# Altium schematic sheet Easy380 Controllo.SchDoc("Easy380 Controllo")
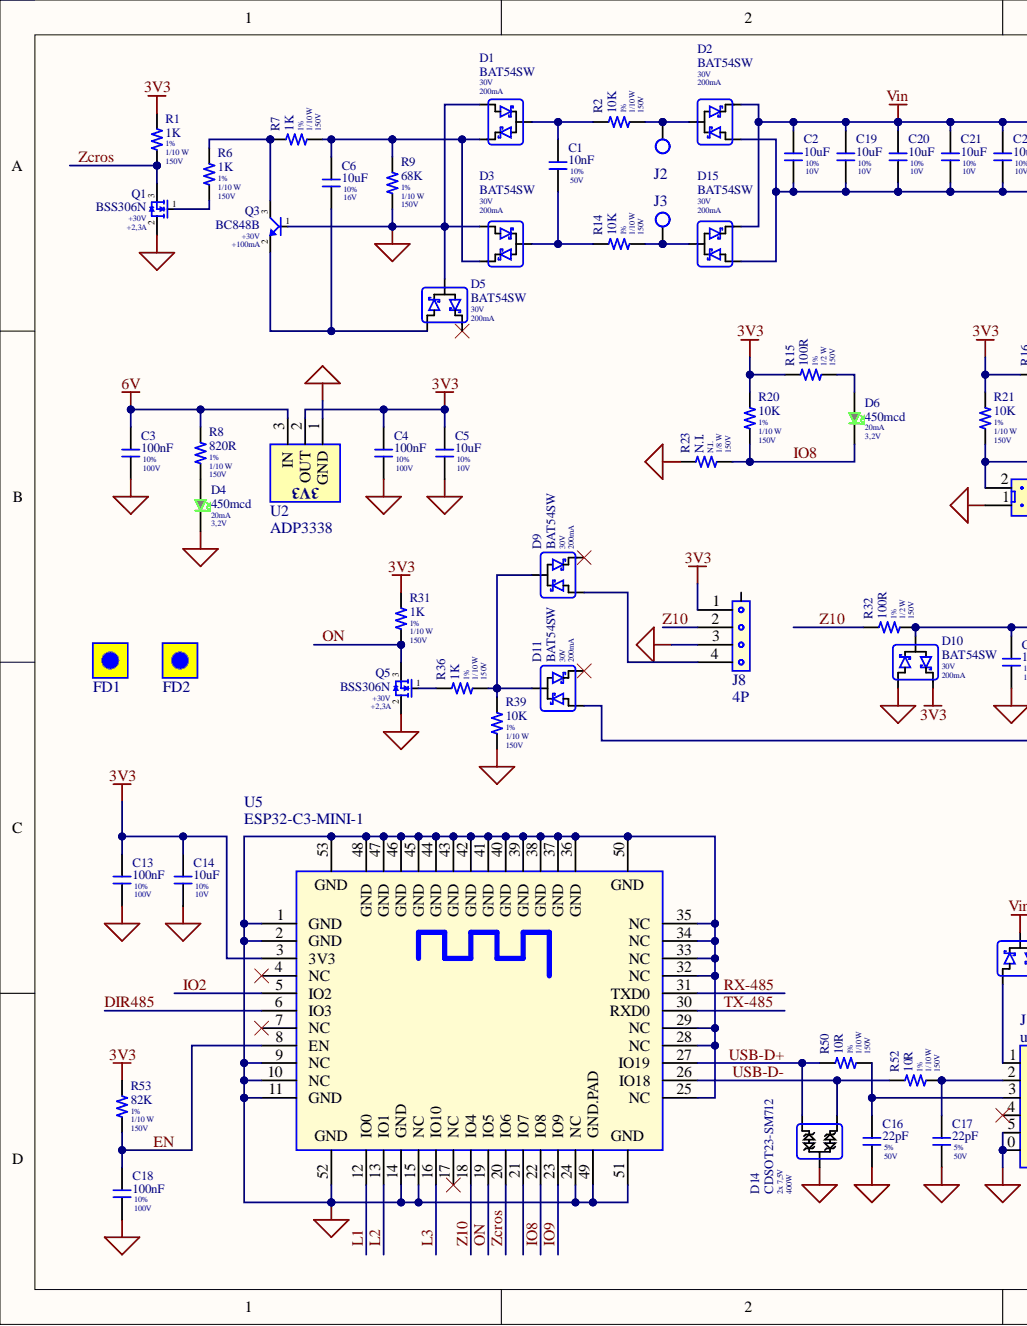
[diagram p0: Easy380 Controllo.SchDoc, left]
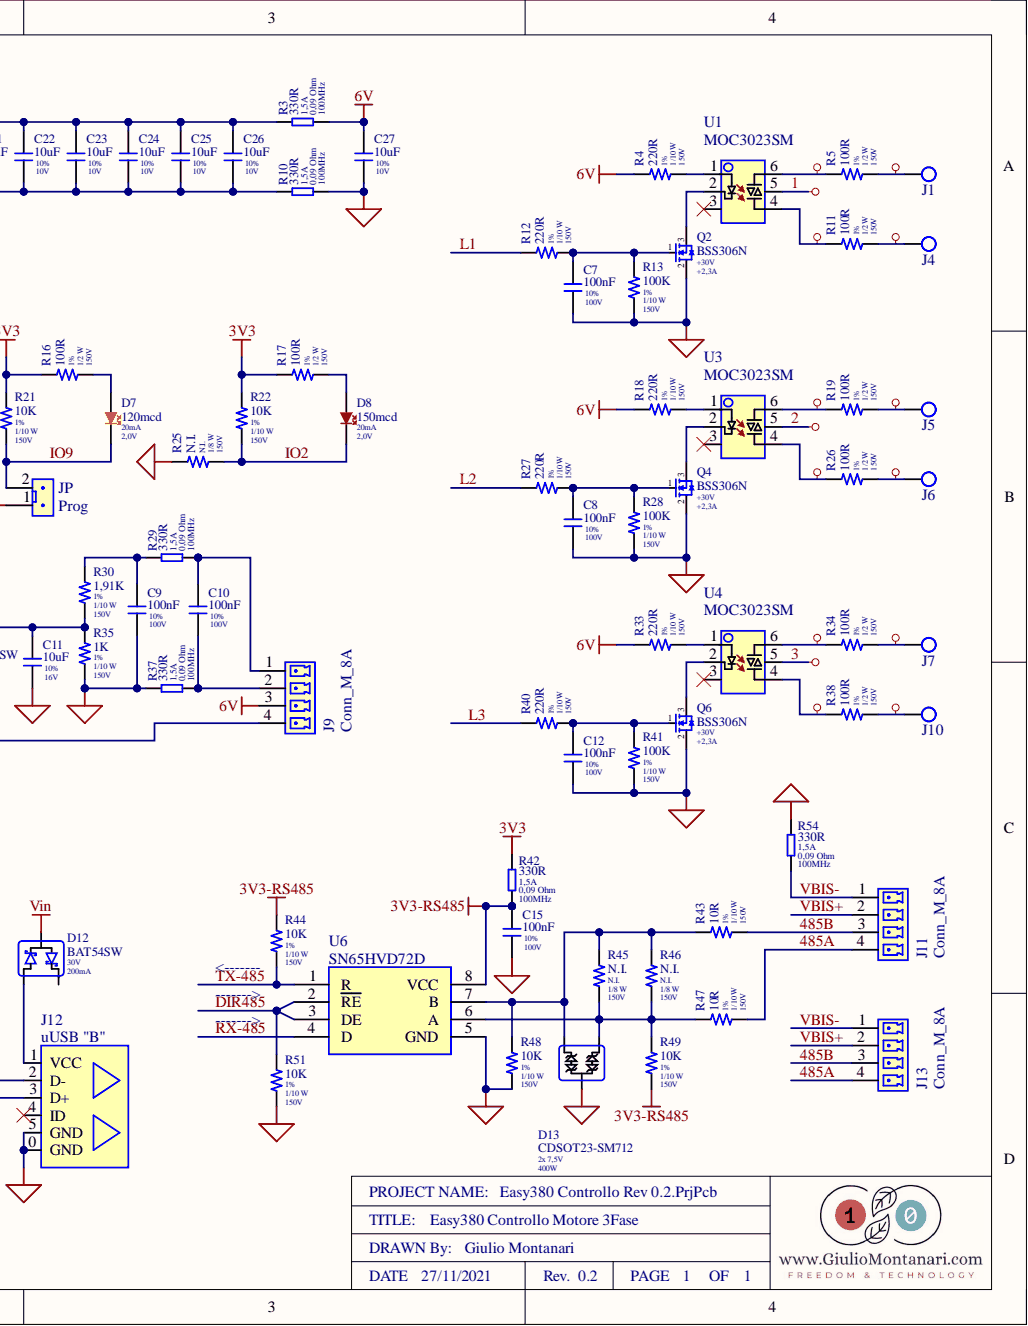
[diagram p1: Easy380 Controllo.SchDoc, right]

## Parts
C1  10nF  Tolerance: 10%  Voltage: 50V  [CAP_0805]
C2  10uF  Tolerance: 10%  Voltage: 10V  [CAP_0805]
C3  100nF  Tolerance: 10%  Voltage: 100V  [CAP_0805]
C4  100nF  Tolerance: 10%  Voltage: 100V  [CAP_0805]
C5  10uF  Tolerance: 10%  Voltage: 10V  [CAP_0805]
C6  10uF  Tolerance: 10%  Voltage: 16V  [CAP_0805]
C7  100nF  Tolerance: 10%  Voltage: 100V  [CAP_0805]
C8  100nF  Tolerance: 10%  Voltage: 100V  [CAP_0805]
C9  100nF  Tolerance: 10%  Voltage: 100V  [CAP_0805]
C10  100nF  Tolerance: 10%  Voltage: 100V  [CAP_0805]
C11  10uF  Tolerance: 10%  Voltage: 16V  [CAP_0805]
C12  100nF  Tolerance: 10%  Voltage: 100V  [CAP_0805]
C13  100nF  Tolerance: 10%  Voltage: 100V  [CAP_0805]
C14  10uF  Tolerance: 10%  Voltage: 10V  [CAP_0805]
C15  100nF  Tolerance: 10%  Voltage: 100V  [CAP_0805]
C16  22pF  Tolerance: 5%  Voltage: 50V  [CAP_0805]
C17  22pF  Tolerance: 5%  Voltage: 50V  [CAP_0805]
C18  100nF  Tolerance: 10%  Voltage: 100V  [CAP_0805]
C19  10uF  Tolerance: 10%  Voltage: 10V  [CAP_0805]
C20  10uF  Tolerance: 10%  Voltage: 10V  [CAP_0805]
C21  10uF  Tolerance: 10%  Voltage: 10V  [CAP_0805]
C22  10uF  Tolerance: 10%  Voltage: 10V  [CAP_0805]
C23  10uF  Tolerance: 10%  Voltage: 10V  [CAP_0805]
C24  10uF  Tolerance: 10%  Voltage: 10V  [CAP_0805]
C25  10uF  Tolerance: 10%  Voltage: 10V  [CAP_0805]
C26  10uF  Tolerance: 10%  Voltage: 10V  [CAP_0805]
C27  10uF  Tolerance: 10%  Voltage: 10V  [CAP_0805]
D1  BAT54SW  Current MAX: 200mA  Voltage MAX: 30V  [SOT323]
D2  BAT54SW  Current MAX: 200mA  Voltage MAX: 30V  [SOT323]
D3  BAT54SW  Current MAX: 200mA  Voltage MAX: 30V  [SOT323]
D4  450mcd  Current: 20mA  Voltage: 3,2V  [LED_G_0805]
D5  BAT54SW  Current MAX: 200mA  Voltage MAX: 30V  [SOT323]
D6  450mcd  Current: 20mA  Voltage: 3,2V  [LED_G_0805]
D7  120mcd  Current: 20mA  Voltage: 2,0V  [LED_O_0805]
D8  150mcd  Current: 20mA  Voltage: 2,0V  [LED_R_0805]
D9  BAT54SW  Current MAX: 200mA  Voltage MAX: 30V  [SOT323]
D10  BAT54SW  Current MAX: 200mA  Voltage MAX: 30V  [SOT323]
D11  BAT54SW  Current MAX: 200mA  Voltage MAX: 30V  [SOT323]
D12  BAT54SW  Current MAX: 200mA  Voltage MAX: 30V  [SOT323]
D13  CDSOT23-SM712  [SOT23]
D14  CDSOT23-SM712  Power: 400W  Voltage: 2x 7,5V  [SOT23]
D15  BAT54SW  Current MAX: 200mA  Voltage MAX: 30V  [SOT323]
FD1  Fiducial  [FIDUCIAL_TL]  (value not drawn)  (no BOM)
FD2  Fiducial  [FIDUCIAL_TL]  (value not drawn)  (no BOM)
J1  PAD  [PAD_3X5]  (value not drawn)  (no BOM)
J2  PAD  [PAD_3X5]  (value not drawn)  (no BOM)
J3  PAD  [PAD_3X5]  (value not drawn)  (no BOM)
J4  PAD  [PAD_3X5]  (value not drawn)  (no BOM)
J5  PAD  [PAD_3X5]  (value not drawn)  (no BOM)
J6  PAD  [PAD_3X5]  (value not drawn)  (no BOM)
J7  PAD  [PAD_3X5]  (value not drawn)  (no BOM)
J8  4P  [B4B-PH-SM4-TB]
J9  Conn_M_8A  [MCV-1,5/4-G-3.5/3,81]
J10  PAD  [PAD_3X5]  (value not drawn)  (no BOM)
J11  Conn_M_8A  [MCV-1,5/4-G-3.5/3,81]
J12  uUSB "B"  [Micro_USB_B_SMD]
J13  Conn_M_8A  [MCV-1,5/4-G-3.5/3,81]
JP  Prog  [B2B-PH-K-S]
Q1  BSS306N  Current MAX: +2,3A  Voltage MAX: +30V  [SOT23]
Q2  BSS306N  Current MAX: +2,3A  Voltage MAX: +30V  [SOT23]
Q3  BC848B  Current MAX: +100mA  Voltage MAX: +30V  [SOT23]
Q4  BSS306N  Current MAX: +2,3A  Voltage MAX: +30V  [SOT23]
Q5  BSS306N  Current MAX: +2,3A  Voltage MAX: +30V  [SOT23]
Q6  BSS306N  Current MAX: +2,3A  Voltage MAX: +30V  [SOT23]
R1  1K  Tolerance: 1%  Work Voltage: 150V  [RES_0805]
R2  10K  Power Rate: 1/10 W  Tolerance: 1%  Work Voltage: 150V  [RES_0805]
R3  Current: 1,5A  DC Resistance: 0,09 Ohm  FRQ: 100MHz  Inductance: 330R  [FER_0805]  (hidden comment: Ferrite)
R4  220R  Power Rate: 1/10 W  Tolerance: 1%  Work Voltage: 150V  [RES_0805]
R5  100R  Power Rate: 1/2 W  Tolerance: 1%  Work Voltage: 150V  [RES_0805]
R6  1K  Power Rate: 1/10 W  Tolerance: 1%  Work Voltage: 150V  [RES_0805]
R7  1K  Power Rate: 1/10 W  Tolerance: 1%  Work Voltage: 150V  [RES_0805]
R8  820R  Tolerance: 1%  Work Voltage: 150V  [RES_0805]
R9  68K  Power Rate: 1/10 W  Tolerance: 1%  Work Voltage: 150V  [RES_0805]
R10  Current: 1,5A  DC Resistance: 0,09 Ohm  FRQ: 100MHz  Inductance: 330R  [FER_0805]  (hidden comment: Ferrite)
R11  100R  Power Rate: 1/2 W  Tolerance: 1%  Work Voltage: 150V  [RES_0805]
R12  220R  Power Rate: 1/10 W  Tolerance: 1%  Work Voltage: 150V  [RES_0805]
R13  100K  Power Rate: 1/10 W  Tolerance: 1%  Work Voltage: 150V  [RES_0805]
R14  10K  Power Rate: 1/10 W  Tolerance: 1%  Work Voltage: 150V  [RES_0805]
R15  100R  Power Rate: 1/2 W  Tolerance: 1%  Work Voltage: 150V  [RES_0805]
R16  100R  Power Rate: 1/2 W  Tolerance: 1%  Work Voltage: 150V  [RES_0805]
R17  100R  Power Rate: 1/2 W  Tolerance: 1%  Work Voltage: 150V  [RES_0805]
R18  220R  Power Rate: 1/10 W  Tolerance: 1%  Work Voltage: 150V  [RES_0805]
R19  100R  Power Rate: 1/2 W  Tolerance: 1%  Work Voltage: 150V  [RES_0805]
R20  10K  Power Rate: 1/10 W  Tolerance: 1%  Work Voltage: 150V  [RES_0805]
R21  10K  Power Rate: 1/10 W  Tolerance: 1%  Work Voltage: 150V  [RES_0805]
R22  10K  Power Rate: 1/10 W  Tolerance: 1%  Work Voltage: 150V  [RES_0805]
R23  N.I.  Power Rate: 1/8 W  Work Voltage: 150V  [RES_0805]
R25  N.I.  Power Rate: 1/8 W  Work Voltage: 150V  [RES_0805]
R26  100R  Power Rate: 1/2 W  Tolerance: 1%  Work Voltage: 150V  [RES_0805]
R27  220R  Power Rate: 1/10 W  Tolerance: 1%  Work Voltage: 150V  [RES_0805]
R28  100K  Power Rate: 1/10 W  Tolerance: 1%  Work Voltage: 150V  [RES_0805]
R29  Current: 1,5A  DC Resistance: 0,09 Ohm  FRQ: 100MHz  Inductance: 330R  [FER_0805]  (hidden comment: Ferrite)
R30  1,91K  Tolerance: 1%  Work Voltage: 150V  [RES_0805]
R31  1K  Power Rate: 1/10 W  Tolerance: 1%  Work Voltage: 150V  [RES_0805]
R32  100R  Power Rate: 1/2 W  Tolerance: 1%  Work Voltage: 150V  [RES_0805]
R33  220R  Power Rate: 1/10 W  Tolerance: 1%  Work Voltage: 150V  [RES_0805]
R34  100R  Power Rate: 1/2 W  Tolerance: 1%  Work Voltage: 150V  [RES_0805]
R35  1K  Power Rate: 1/10 W  Tolerance: 1%  Work Voltage: 150V  [RES_0805]
R36  1K  Power Rate: 1/10 W  Tolerance: 1%  Work Voltage: 150V  [RES_0805]
R37  Current: 1,5A  FRQ: 100MHz  Inductance: 330R  [FER_0805]  (hidden comment: Ferrite)
R38  100R  Power Rate: 1/2 W  Tolerance: 1%  Work Voltage: 150V  [RES_0805]
R39  10K  Power Rate: 1/10 W  Tolerance: 1%  Work Voltage: 150V  [RES_0805]
R40  220R  Power Rate: 1/10 W  Tolerance: 1%  Work Voltage: 150V  [RES_0805]
R41  100K  Power Rate: 1/10 W  Tolerance: 1%  Work Voltage: 150V  [RES_0805]
R42  Current: 1,5A  FRQ: 100MHz  Inductance: 330R  [FER_0805]  (hidden comment: Ferrite)
R43  10R  Power Rate: 1/10 W  Tolerance: 1%  Work Voltage: 150V  [RES_0805]
R44  10K  Tolerance: 1%  Work Voltage: 150V  [RES_0805]
R45  N.I.  Power Rate: 1/8 W  Work Voltage: 150V  [RES_0805]
R46  N.I.  Power Rate: 1/8 W  Work Voltage: 150V  [RES_0805]
R47  10R  Power Rate: 1/10 W  Tolerance: 1%  Work Voltage: 150V  [RES_0805]
R48  10K  Power Rate: 1/10 W  Tolerance: 1%  Work Voltage: 150V  [RES_0805]
R49  10K  Power Rate: 1/10 W  Tolerance: 1%  Work Voltage: 150V  [RES_0805]
R50  10R  Power Rate: 1/10 W  Tolerance: 1%  Work Voltage: 150V  [RES_0805]
R51  10K  Power Rate: 1/10 W  Tolerance: 1%  Work Voltage: 150V  [RES_0805]
R52  10R  Power Rate: 1/10 W  Tolerance: 1%  Work Voltage: 150V  [RES_0805]
R53  82K  Power Rate: 1/10 W  Tolerance: 1%  Work Voltage: 150V  [RES_0805]
R54  Current: 1,5A  DC Resistance: 0,09 Ohm  FRQ: 100MHz  Inductance: 330R  [FER_0805]  (hidden comment: Ferrite)
U1  MOC3023SM  [MOC3023SM]
U2  ADP3338  [SOT223]
U3  MOC3023SM  [MOC3023SM]
U4  MOC3023SM  [MOC3023SM]
U5  ESP32-C3-MINI-1  [ESP32-C3-MINI-1]
U6  SN65HVD72D  [SO-8]

## Nets
3V3: C4.1 C5.1 C13.1 C14.1 D10.2 J8.1 R1.1 R15.1 R16.1 R17.1 R20.1 R21.1 R22.1 R31.1 R42.1 R53.1 U2.2 U5.3(3V3)
3V3-RS485: C15.1 R42.2 R44.1 R49.2 U6.8(VCC)
6V: C3.1 C27.1 J9.3 R3.2 R4.1 R8.1 R18.1 R33.1 U2.3
GND: C3.2 C4.2 C5.2 C7.2 C8.2 C9.2 C11.2 C12.2 C13.2 C14.2 C15.2 C16.2 C17.2 C18.2 C27.2 D1.1 D3.1 D4.K D5.3 D10.1 D13.3 D14.3 J8.3 J12.0(GND) J12.5(GND) JP.1 Q1.2 Q2.2 Q3.1 Q4.2 Q5.2 Q6.2 R9.2 R10.2 R13.2 R23.1 R25.1 R28.2 R35.2 R37.1 R39.2 R41.2 R48.2 R51.2 R54.1 U2.1 U5.1(GND) U5.2(GND) U5.9(NC) U5.10(NC) U5.11(GND) U5.14(GND) U5.15(NC) U5.24(NC) U5.25(NC) U5.28(NC) U5.29(NC) U5.32(NC) U5.33(NC) U5.34(NC) U5.35(NC) U5.36(GND) U5.37(GND) U5.38(GND) U5.39(GND) U5.40(GND) U5.41(GND) U5.42(GND) U5.43(GND) U5.44(GND) U5.45(GND) U5.46(GND) U5.47(GND) U5.48(GND) U5.49(GND.PAD) U5.50 U5.51 U5.52 U5.53 U6.5(GND)
Vin: C2.1 C19.1 C20.1 C21.1 C22.1 C23.1 C24.1 C25.1 C26.1 D2.2 D12.3 D15.2 R3.1
1: U1.5
2: U3.5
3: U4.5
485A: J11.4 J13.4 R47.2
485B: J11.3 J13.3 R43.2
DIR485: R51.1 U5.6(IO3) U6.2(RE) U6.3(DE)
EN: C18.1 R53.2 U5.8(EN)
IO2: D8.K R22.2 R25.2 U5.5(IO2)
IO8: D6.K R20.2 R23.2 U5.22(IO8)
IO9: D7.K JP.2 R21.2 U5.23(IO9)
L1: R12.1 U5.12(IO0)
L2: R27.1 U5.13(IO1)
L3: R40.1 U5.16(IO10)
ON: Q5.3 R31.2 U5.19(IO5)
RX-485: U5.31(TXD0) U6.4(D)
TX-485: R44.2 U5.30(RXD0) U6.1(R)
USB-D+: D14.1 R50.1 U5.27(IO19)
USB-D-: D14.2 R52.1 U5.26(IO18)
VBIS+: J11.2 J13.2
VBIS-: J11.1 J13.1 R54.2
Z10: J8.2 R32.1 U5.18(IO4)
Zcros: Q1.3 R1.2 U5.20(IO6)
NetC1_1: C1.1 D1.3 R2.1
NetC1_2: C1.2 D3.3 R14.1
NetC2_2: C2.2 C19.2 C20.2 C21.2 C22.2 C23.2 C24.2 C25.2 C26.2 D2.1 D15.1 R10.1
NetC6_1: C6.1 D1.2 D3.2 R7.2 R9.1
NetC6_2: C6.2 D5.1 Q3.2
NetC7_1: C7.1 Q2.1 R12.2 R13.1
NetC8_1: C8.1 Q4.1 R27.2 R28.1
NetC9_1: C9.1 R29.1 R30.1
NetC10_1: C10.1 J9.1 R29.2
NetC10_2: C10.2 J9.2 R37.2
NetC11_1: C11.1 D10.3 R30.2 R32.2 R35.1
NetC12_1: C12.1 Q6.1 R40.2 R41.1
NetC16_1: C16.1 J12.3(D+) R50.2
NetC17_1: C17.1 J12.2(D-) R52.2
NetD2_3: D2.3 J2.1 R2.2
NetD4_A: D4.A R8.2
NetD6_A: D6.A R15.2
NetD7_A: D7.A R16.2
NetD8_A: D8.A R17.2
NetD9_1: D9.1 J8.4
NetD9_3: D9.3 D11.3 R36.2 R39.1
NetD11_1: D11.1 J9.4
NetD12_1: D12.1 J12.1(VCC)
NetD13_1: D13.1 R43.1 R45.1 R46.1 R48.1 U6.7(B)
NetD13_2: D13.2 R45.2 R46.2 R47.1 R49.1 U6.6(A)
NetD15_3: D15.3 J3.1 R14.2
NetJ1_1: J1.1 R5.2
NetJ4_1: J4.1 R11.2
NetJ5_1: J5.1 R19.2
NetJ6_1: J6.1 R26.2
NetJ7_1: J7.1 R34.2
NetJ10_1: J10.1 R38.2
NetQ1_1: Q1.1 R6.2
NetQ2_3: Q2.3 U1.2
NetQ3_3: Q3.3 R6.1 R7.1
NetQ4_3: Q4.3 U3.2
NetQ5_1: Q5.1 R36.1
NetQ6_3: Q6.3 U4.2
NetR4_2: R4.2 U1.1
NetR5_1: R5.1 U1.6
NetR11_1: R11.1 U1.4
NetR18_2: R18.2 U3.1
NetR19_1: R19.1 U3.6
NetR26_1: R26.1 U3.4
NetR33_2: R33.2 U4.1
NetR34_1: R34.1 U4.6
NetR38_1: R38.1 U4.4

Not connected: D5 2; D9 2; D11 2; D12 2; J8 0; J12 4(ID); U1 3; U3 3; U4 3; U5 4(NC) 7(NC) 17(NC) 21(IO7)

## Library data of the parts
C1 = COCS557065000103: Cap 10nF+/-10%;  50V; Case 0805; X7R; Manufactuer 1: Samsung; Manufactuer 2: AVX; Manufactuer 3: Walsin; Database Table Name: Capacitors CERAMIC; Man 1 P\N: CL21B103KBFNNNE; Man 2 P\N: 08055C103KAT2A; Man 3 P\N: 0805B103K500CT; Mounting Method: SMD; Temp Range: -55°C to +125°C; Capacitance: 10nF; Component Kind: Standard; ComponentLink1Description: Data Scheet Samsung; ComponentLink2Description: Data Scheet AVX; ComponentLink3Description: Data Scheet Walsin; Library Name: Database_Libs.DbLib; Part Number: COCS557065000103; Price 1: 0,0188; Price 2: 0,041; Price 3: 0,03; ComponentLink1URL: C:\Sharing\Lib ALTIUM\DataBaseLib\DataScheet\Condensatori Ceramici\Samsung_CL.pdf; ComponentLink2URL: C:\Sharing\Lib ALTIUM\DataBaseLib\DataScheet\Condensatori Ceramici\AVX_X7R.pdf; ComponentLink3URL: C:\Sharing\Lib ALTIUM\DataBaseLib\DataScheet\Condensatori Ceramici\Walsing_MMLC.pdf
C2 C5 C14 C19-C27 = COCS 557061000106: Cap 10uF+/-10%;  10V; Case 0805; X5R; Manufactuer 1: Samsung Electro-Mechanics; Manufactuer 2: Taiyo Yoden  Taiyo Yuden; Manufactuer 3: Walsin; Database Table Name: Capacitors CERAMIC; Man 1 P\N: CL21A106KPFNNNE; Man 2 P\N: LMK212ABJ106KG-T; Man 3 P\N: 0805X106K100CT; Mounting Method: SMD; Temp Range: -55°C to +125°C; Capacitance: 10uF; Component Kind: Standard; ComponentLink1Description: Data Scheet Samsung; ComponentLink2Description: Data Scheet Taiyo Yoden; ComponentLink3Description: Data Scheet Walsin; Library Name: Database_Libs.DbLib; Part Number: COCS 557061000106; Price 1: 0,0139; Price 2: 0,0147; Price 3: 0,0216; ComponentLink1URL: C:\Sharing\Lib ALTIUM\DataBaseLib\DataScheet\Condensatori Ceramici\Samsung_CL.pdf; ComponentLink2URL: C:\Sharing\Lib ALTIUM\DataBaseLib\DataScheet\Condensatori Ceramici\TaiyoYuden_LMK212ABJ106KG-T.pdf; ComponentLink3URL: C:\Sharing\Lib ALTIUM\DataBaseLib\DataScheet\Condensatori Ceramici\Walsing_MMLC.pdf
C3 C4 C7-C10 C12 C13 C15 C18 = COCS 557061010104: Cap 100nF+/-10%;  100V; Case 0805; X7R; Manufactuer 1: Samsung; Manufactuer 2: AVX; Manufactuer 3: Walsin; Database Table Name: Capacitors CERAMIC; Man 1 P\N: CL21B104KBCNNNC; Man 2 P\N: 08051C104KAT2A; Man 3 P\N: 0805B104K101CT; Mounting Method: SMD; Temp Range: -55°C to +125°C; Capacitance: 100nF; Component Kind: Standard; ComponentLink1Description: Data Scheet Samsung; ComponentLink2Description: Data Scheet AVX; ComponentLink3Description: Data Scheet Walsin; Library Name: Database_Libs.DbLib; Part Number: COCS 557061010104; Price 1: 0,0092; Price 2: 0,059; Price 3: 0,056; ComponentLink1URL: C:\Sharing\Lib ALTIUM\DataBaseLib\DataScheet\Condensatori Ceramici\Samsung_CL.pdf; ComponentLink2URL: C:\Sharing\Lib ALTIUM\DataBaseLib\DataScheet\Condensatori Ceramici\AVX_X7R.pdf; ComponentLink3URL: C:\Sharing\Lib ALTIUM\DataBaseLib\DataScheet\Condensatori Ceramici\Walsing_MMLC.pdf
C6 C11 = COCS 557061600106: Cap 10uF+/-10%;  16V; Case 0805; X7R; Manufactuer 1: Samsung; Database Table Name: Capacitors CERAMIC; Man 1 P\N: CL21B106KOQNNNE; Mounting Method: SMD; Temp Range: -55°C to +125°C; Capacitance: 10uF; Component Kind: Standard; ComponentLink1Description: Data Scheet Samsung; Library Name: Database_Libs.DbLib; Part Number: COCS 557061600106; Price 1: 0,06; ComponentLink1URL: C:\Sharing\Lib ALTIUM\DataBaseLib\DataScheet\Condensatori Ceramici\Samsung_CL.pdf
C16 C17 = COCS 557065000220: Cap 22pF+/-5%;  50V; Case 0805; COG; Manufactuer 1: Samsung; Manufactuer 2: AVX; Manufactuer 3: Walsin; Database Table Name: Capacitors CERAMIC; Man 1 P\N: CL21C220JB61PNC; Man 2 P\N: 08055A220JAT2A; Man 3 P\N: 0805N220J500CT; Mounting Method: SMD; Temp Range: -55°C to +125°C; Capacitance: 22pF; Component Kind: Standard; ComponentLink1Description: Data Scheet Samsung; ComponentLink2Description: Data Scheet AVX; ComponentLink3Description: Data Scheet Walsin; Library Name: Database_Libs.DbLib; Part Number: COCS 557065000220; Price 1: 0,028; Price 2: 0,0182; Price 3: 0,023; ComponentLink1URL: C:\Sharing\Lib ALTIUM\DataBaseLib\DataScheet\Condensatori Ceramici\Samsung_CL.pdf; ComponentLink2URL: C:\Sharing\Lib ALTIUM\DataBaseLib\DataScheet\Condensatori Ceramici\AVX_C0G_NP0.pdf; ComponentLink3URL: C:\Sharing\Lib ALTIUM\DataBaseLib\DataScheet\Condensatori Ceramici\Walsing_MMLC.pdf
D1-D3 D5 D9-D12 D15 = DIOS 215BAT54SW00: Boble Schottky Diode BAT54SW; Vmax 30V; Imax 200mA; SOT323; Manufactuer 1: DIODES; Manufactuer 2: ST; Manufactuer 3: Nexperia; Database Table Name: Diode Rectifire; Man 1 P\N: BAT54SW-7-F; Man 2 P\N: BAT54SWFILMY; Man 3 P\N: BAT54SWF; Mounting Method: SMD; Temp Range: -°C to +°C; COD: BAT54SW; Component Kind: Standard; ComponentLink1Description: Data Scheet Diodes; ComponentLink2Description: Data Scheet ST; ComponentLink3Description: Data Scheet Nexperia; Library Name: Database_Libs.DbLib; Part Number: DIOS 215BAT54SW00; Price 1: 0,044; Price 2: 0,047; Price 3: 0,025; ComponentLink1URL: C:\Sharing\Lib ALTIUM\DataBaseLib\DataScheet\Diode\Diodes_BAT54.pdf; ComponentLink2URL: C:\Sharing\Lib ALTIUM\DataBaseLib\DataScheet\Diode\ST_bat54-y.pdf; ComponentLink3URL: C:\Sharing\Lib ALTIUM\DataBaseLib\DataScheet\Diode\Experia_BAT54W.pdf
D4 D6 = LEDS 9AC080GS7500: LED Green Waterclear; Case 0805; Vf 3,2V; If 20mA; 450mcd; Manufactuer 1: Wurth Elektronik; Database Table Name: Diode LED; Man 1 P\N: 150080GS75000; Mounting Method: SMD; Temp Range: -40°C to +85°C; Brightness: 450mcd; Component Kind: Standard; ComponentLink1Description: Datascheet WE; Library Name: Database_Libs.DbLib; Part Number: LEDS 9AC080GS7500; Price 1: 0,113; ComponentLink1URL: C:\Sharing\Lib ALTIUM\DataBaseLib\DataScheet\Diode\LED_G_150080GS75000.pdf
D7 = LEDS DAC080YS7500: LED Orange Waterclear; Case 0805; Vf 2,0V; If 20mA; 120mcd; Manufactuer 1: Wurth Elektronik; Database Table Name: Diode LED; Man 1 P\N: 150080YS75000; Mounting Method: SMD; Temp Range: -40°C to +85°C; Brightness: 120mcd; Component Kind: Standard; ComponentLink1Description: Datascheet WE; Library Name: Database_Libs.DbLib; Part Number: LEDS DAC080YS7500; Price 1: 0,112; ComponentLink1URL: C:\Sharing\Lib ALTIUM\DataBaseLib\DataScheet\Diode\LED_O_150080YS75000.pdf
D8 = LEDS 2AC080RS7500: LED Red Waterclear; Case 0805; Vf 2,0V; If 20mA; 150mcd; Manufactuer 1: Wurth Elektronik; Database Table Name: Diode LED; Man 1 P\N: 150080RS75000; Mounting Method: SMD; Temp Range: -40°C to +85°C; Brightness: 150mcd; Component Kind: Standard; ComponentLink1Description: Datascheet WE; Library Name: Database_Libs.DbLib; Part Number: LEDS 2AC080RS7500; Price 1: 0,106; ComponentLink1URL: C:\Sharing\Lib ALTIUM\DataBaseLib\DataScheet\Diode\LED_R_150080RS75000.pdf
D13 = ZENS 52350STO23SM712: Diode TVS; ESD Prot MAX 30KV; Vbr 2x7,5V; Ppk 400W; Manufactuer 1: Bourns; Database Table Name: Diode ZENER/ESD; Mounting Method: SMD; Tolerance: 10%; Voltage: 2x 7,5V; COD: CDSOT23-SM712; Component Kind: Standard; ComponentLink1Description: Datascheet Bourns; Library Name: Database_Libs.DbLib; Man 1 P\N: CDSOT23-SM712; Part Number: ZENS 52350STO23SM712; Power: 400W; Price 1: 0,55; ComponentLink1URL: C:\Sharing\Lib ALTIUM\DataBaseLib\DataScheet\Diode\DSOT23-SM712.pdf
D14 = ZENS 52350STO23SM712: Diode TVS; ESD Prot MAX 30KV; Vbr 2x7,5V; Ppk 400W; Manufactuer 1: Bourns; Database Table Name: Diode ZENER/ESD; Mounting Method: SMD; Tolerance: 10%; COD: CDSOT23-SM712; Component Kind: Standard; ComponentLink1Description: Datascheet Bourns; Library Name: Database_Libs.DbLib; Man 1 P\N: CDSOT23-SM712; Part Number: ZENS 52350STO23SM712; Price 1: 0,55; ComponentLink1URL: C:\Sharing\Lib ALTIUM\DataBaseLib\DataScheet\Diode\DSOT23-SM712.pdf
FD1 FD2 = Fiducial: Reference P&P; Library Name: Mechanical.SchLib
J1-J7 J10 = PAD_Simple: Library Name: Connectors.SchLib
J8 = Conn_M_4P_2A_2.00mm_SMD: ConSMD 4p B4B-PH-SM4-TB Ing.Alto 100V 2A; Manufactuer 1: JST; Part Number: CON 0002A1012004; Man 1 P\N: B4B-PH-SM4-TB(LF)(SN); Component Kind: Standard; I max: 2A; Library Name: Connectors.SchLib; Vmax: 100V
J9 J11 J13 = Conn_M_4P_8A_3.5/3.81mm: Conn Male; PCB Mount; 4pol; Imax 8A; Vmax 300V; Pich 3.5 or 3.81mm; Manufactuer 1: WE; Manufactuer 3: Phoenix; Part Number: CON 300V8A4P350381MM; Man 1 P\N: 691321300004; Man 2 P\N: 691321100004; Man 3 P\N: 1803442; Component Kind: Standard; I max: 8A; Library Name: Connectors.SchLib; Manufactuer 2: WE; Price 1: 0,66; Vmax: 300V
J12 = USB 2.0 Tipo Micro B: Micro USB 2.0 Tipo B Presa Connettore 5 P; Manufactuer 1: Amphenol; Part Number: MORS 0101181920001LF; Man 1 P\N: 10118192-0001LF; Cod. DigiKey: 609-4613-2-ND; Component Kind: Standard; ComponentLink1Description: Datascheet Amphenol; Library Name: Connectors.SchLib; Price: 0,311; ComponentLink1URL: C:\Sharing\Lib ALTIUM\Simple\DataScheet\Connectors\USB\USB_Micro_Amphenol.pdf
JP = Conn_M_2P_2A_2.00mm: Jumper proigrammazione Pich 2.00/2.54mm; Component Kind: Standard; ComponentLink1Description: Datascheet JST; Library Name: Connectors.SchLib
Q1 Q2 Q4-Q6 = TRXS 11000BSS306N: Small Singan N-FET; Vds+30V; Id+2,3A; Vgs +/-20V; SOT23; Manufactuer 1: Infineon; Manufactuer 2: Nexperia; Database Table Name: Transistor; Man 1 P\N: BSS306N H6327; Man 2 P\N: PMV100ENEAR; Mounting Method: SMD; COD: BSS306N; Component Kind: Standard; ComponentLink1Description: Data Scheet Infineon; ComponentLink2Description: Data Scheet Nexperia; Library Name: Database_Libs.DbLib; Part Number: TRXS 11000BSS306N; Price 1: 0,084; Price 2: 0,098; Vgs: +/- 20V; ComponentLink1URL: C:\Sharing\Lib ALTIUM\DataBaseLib\DataScheet\Transistor\Infineon_BSS306N.pdf; ComponentLink2URL: C:\Sharing\Lib ALTIUM\DataBaseLib\DataScheet\Transistor\Nexperia_PMV100ENEA.pdf
Q3 = TRXS 110000BC848B: NPN General Purpose; Vce+30V; Ic+100mA; Vbe+/-5V; SOT23; Manufactuer 1: ON Semiconductor; Manufactuer 2: Rohm Semiconductor; Manufactuer 3: Nexperia USA Inc.; Database Table Name: Transistor; Man 1 P\N: SBC848BLT1G; Man 2 P\N: BC848BHZGT116; Man 3 P\N: BC848B,235; Mounting Method: SMD; Vgs: +5V; COD: BC848B; Component Kind: Standard; ComponentLink1Description: Datascheet ON; ComponentLink2Description: Datascheet ROHM; ComponentLink3Description: Datascheet Nexperia; Library Name: Database_Libs.DbLib; Part Number: TRXS 110000BC848B; Price 1: 0,14; Price 2: 0,14; Price 3: 0,11; ComponentLink1URL: C:\Sharing\Lib ALTIUM\DataBaseLib\DataScheet\Transistor\ON_BC846-849ALT1-D.pdf; ComponentLink2URL: C:\Sharing\Lib ALTIUM\DataBaseLib\DataScheet\Transistor\ROHM_bc848bhzg-e.pdf; ComponentLink3URL: C:\Sharing\Lib ALTIUM\DataBaseLib\DataScheet\Transistor\Nexperia_BC848_SER.pdf
R1 R6 R7 R31 R35 R36 = RESS 4170310B0102: Res Thick Film; 1K+/-1%;  1/10W; Case 0805; 150V; Manufactuer 1: Kamaya; Man 1 P\N: RMC1/10102FTP; Mounting Method: SMD; Component Kind: Standard; ComponentLink1Description: Datascheet Kamaya; ComponentLink1URL: Datascheet\Resistors\Kamaya_RMC.pdf; Database Table Name: Resistors 0805; Library Name: Database_Libs.DbLib; Part Number: RESS 4170310B0102; Resistance: 1K
  R1: Power Rate: 1/10 W; Price 1: 0
  R6 R7 R31 R36: Price 1: 0
  R35: Price 1: 0,02
R2 R14 R20-R22 R39 R44 R48 R49 R51 = RESS 4170310B0103: Res Thick Film; 10K+/-1%;  1/10W; Case 0805; 150V; Manufactuer 1: Kamaya; Man 1 P\N: RMC1/10103FTP; Mounting Method: SMD; Component Kind: Standard; ComponentLink1Description: Datascheet Kamaya; ComponentLink1URL: Datascheet\Resistors\Kamaya_RMC.pdf; Database Table Name: Resistors 0805; Library Name: Database_Libs.DbLib; Part Number: RESS 4170310B0103; Price 1: 0; Resistance: 10K
  R44: Power Rate: 1/10 W
R3 R10 R29 R37 R42 R54 = INPS 718052010221: Fer 330@100MHz; 0,09ohm;  1,5A; Case 0805;; Manufactuer 1: Murata; Database Table Name: Ferriti SMD; Man 1 P\N: BLM21PG331SN1; Man 3 P\N: LQW18ANR22J8ZD; Mounting Method: SMD; Component Kind: Standard; ComponentLink1Description: Murata Datascheet; Library Name: Database_Libs.DbLib; Part Number: INPS 718052010221; Price 1: 0,2; ComponentLink1URL: C:\Sharing\Lib ALTIUM\DataBaseLib\DataScheet\Ferrite\Burata_BLM.pdf
  R37 R42: DC Resistance: 0,09 Ohm
R4 R12 R18 R27 R33 R40 = RESS 4170310B0221: Res Thick Film; 220R+/-1%;  1/10W; Case 0805; 150V; Manufactuer 1: Kamaya; Man 1 P\N: RMC1/10221FTP; Mounting Method: SMD; Component Kind: Standard; ComponentLink1Description: Datascheet Kamaya; ComponentLink1URL: Datascheet\Resistors\Kamaya_RMC.pdf; Database Table Name: Resistors 0805; Library Name: Database_Libs.DbLib; Part Number: RESS 4170310B0221; Price 1: 0,02; Resistance: 220R
R5 R11 R15-R17 R19 R26 R32 R34 R38 = RESS 4170350B0101: Res Thick Film; 100R+/-1%;  1/2W; Case 0805; 150V; Manufactuer 1: Vishay / Dale; Manufactuer 2: Panasonic; Man 1 P\N: CRCW0805100RFKEAHP; Man 2 P\N: ERJ-P06F1000V; Mounting Method: SMD; Component Kind: Standard; ComponentLink1Description: Datascheet Vishay; ComponentLink1URL: Datascheet\Resistors\Vishay_CRCW-HP.pdf; ComponentLink2Description: Datascheet Panasonic; ComponentLink2URL: Datascheet\Resistors\Panasonic_ERJP.pdf; Database Table Name: Resistors 0805; Library Name: Database_Libs.DbLib; Part Number: RESS 4170350B0101; Price 1: 0,079; Price 2: 0,031; Resistance: 100R
R8 = RESS 4170310B0821: Res Thick Film; 820R+/-1%;  1/10W; Case 0805; 150V; Manufactuer 1: Kamaya; Man 1 P\N: RMC1/10821FTP; Mounting Method: SMD; Component Kind: Standard; ComponentLink1Description: Datascheet Kamaya; ComponentLink1URL: Datascheet\Resistors\Kamaya_RMC.pdf; Database Table Name: Resistors 0805; Library Name: Database_Libs.DbLib; Part Number: RESS 4170310B0821; Power Rate: 1/10 W; Price 1: 0,02; Resistance: 820R
R9 = RESS 4170310B0683: Res Thick Film; 68K+/-1%;  1/10W; Case 0805; 150V; Manufactuer 1: Kamaya; Man 1 P\N: RMC1/10683FTP; Mounting Method: SMD; Component Kind: Standard; ComponentLink1Description: Datascheet Kamaya; ComponentLink1URL: Datascheet\Resistors\Kamaya_RMC.pdf; Database Table Name: Resistors 0805; Library Name: Database_Libs.DbLib; Part Number: RESS 4170310B0683; Price 1: 0,02; Resistance: 68K
R13 R28 R41 = RESS 4170310B0104: Res Thick Film; 100K+/-1%;  1/10W; Case 0805; 150V; Manufactuer 1: Kamaya; Man 1 P\N: RMC1/10104FTP; Mounting Method: SMD; Component Kind: Standard; ComponentLink1Description: Datascheet Kamaya; ComponentLink1URL: Datascheet\Resistors\Kamaya_RMC.pdf; Database Table Name: Resistors 0805; Library Name: Database_Libs.DbLib; Part Number: RESS 4170310B0104; Price 1: 0,02; Resistance: 100K
R23 R25 R45 R46 = N.I.: N.I.;  1/8W; Case 0805; 150V; Mounting Method: SMD; Component Kind: Standard; Database Table Name: Resistors 0805; Library Name: Database_Libs.DbLib; Part Number: N.I.; Price 1: 0; Resistance: N.I.; Tolerance: N.I.
R30 = RESS 4170310B1911: Res Thick Film; 1,91K+/-1%;  1/10W; Case 0805; 150V; Manufactuer 1: Vishay / Dale; Man 1 P\N: CRCW08051K91FKEA; Mounting Method: SMD; Component Kind: Standard; ComponentLink1Description: Datascheet Vishay; ComponentLink1URL: Datascheet\Resistors\Vishay_DCRCW.pdf; Database Table Name: Resistors 0805; Library Name: Database_Libs.DbLib; Part Number: RESS 4170310B1911; Power Rate: 1/10 W; Price 1: 0,02; Resistance: 1,91K
R43 R47 R50 R52 = RESS 4170310B0100: Res Thick Film; 10R+/-1%;  1/10W; Case 0805; 150V; Manufactuer 1: Kamaya; Man 1 P\N: RMC1/10100FTP; Mounting Method: SMD; Component Kind: Standard; ComponentLink1Description: Datascheet Kamaya; ComponentLink1URL: Datascheet\Resistors\Kamaya_RMC.pdf; Database Table Name: Resistors 0805; Library Name: Database_Libs.DbLib; Part Number: RESS 4170310B0100; Price 1: 0; Resistance: 10R
R53 = RESS 4170310B0823: Res Thick Film; 82K+/-1%;  1/10W; Case 0805; 150V; Manufactuer 1: Kamaya; Man 1 P\N: RMC1/10823FTP; Mounting Method: SMD; Component Kind: Standard; ComponentLink1Description: Datascheet Kamaya; ComponentLink1URL: Datascheet\Resistors\Kamaya_RMC.pdf; Database Table Name: Resistors 0805; Library Name: Database_Libs.DbLib; Part Number: RESS 4170310B0823; Price 1: 0,02; Resistance: 82K
U1 U3 U4 = MOC3023SM: Random Phase Triac Driver Output Optrocoupler; Manufactuer 1: On Semiconductor; Mounting Method: SMD; Component Kind: Standard; ComponentLink1Description: Datascheet On Semi; Library Name: OptoPart.SchLib; Man 1 P\N: MOC3023SM; Price 1: 0,225; ComponentLink1URL: C:\Sharing\Lib ALTIUM\Simple\DataScheet\MOC3023M.pdf
U2 = 3V3 ADP3338: ADP3338 UltraLOW-IQ; LDO Reg; 1A 3.3Vout; Case SOT223; Manufactuer 1: Analog Devices; Part Number: INGS 118000ADP3383V3; Man 1 P\N: ADP3338AKCZ-3.3RL7; Man 2 P\N: ADP3338AKCZ-3.3-RL; Mounting Method: SMD; Component Kind: Standard; ComponentLink1Description: Datascheet Analog Device; Library Name: PowerSupply.SchLib; Manufactuer 2: Analog Devices; Price 1: 1,4900; Price 2: 1,2900; ComponentLink1URL: C:\Sharing\Lib ALTIUM\Simple\DataScheet\Power Supply\ADP3338_Analog.pdf
U5 = ESP32-C3-MINI-1: ESP32-C3-MINI-1 Small­sized 2.4 GHz Wi­Fand Bluetooth 5 module; RISC­V single­core uC ESP32­C3; 4 MB flash; 15 GPIOs; On­board PCB antenna; Manufactuer 1: Espressif Systems; Part Number: INGS 000000ESP32C3M1; Man 1 P\N: ESP32-C3-MINI-1-H4; Mounting Method: SMD; Component Kind: Standard; ComponentLink1Description: Datascheet Espressif; ComponentLink2Description: Core_ESP32_DataScheet; Library Name: Microcontroller.SchLib; Price 1: 1,61; ComponentLink1URL: C:\Sharing\Lib ALTIUM\Simple\DataScheet\uC\ESP32-C3-MINI-1_Datasheet.pdf; ComponentLink2URL: C:\Sharing\Lib ALTIUM\Simple\DataScheet\uC\ESP32-C3_Datasheet.pdf
U6 = SN65HVD72D: RS485 3v3 transceiver; Manufactuer 1: Texas Instruments; Part Number: INGS 00000SN65HVD72D; Mounting Method: SMD; Component Kind: Standard; ComponentLink1Description: Datascheet; Library Name: Integrated.SchLib; Man 1 P\N: SN65HVD72D; Price 1: 1,7200 €; ComponentLink1URL: C:\Sharing\Lib ALTIUM\Simple\DataScheet\sn65hvd72.pdf
